# Altium schematic sheet Sensors.SchDoc
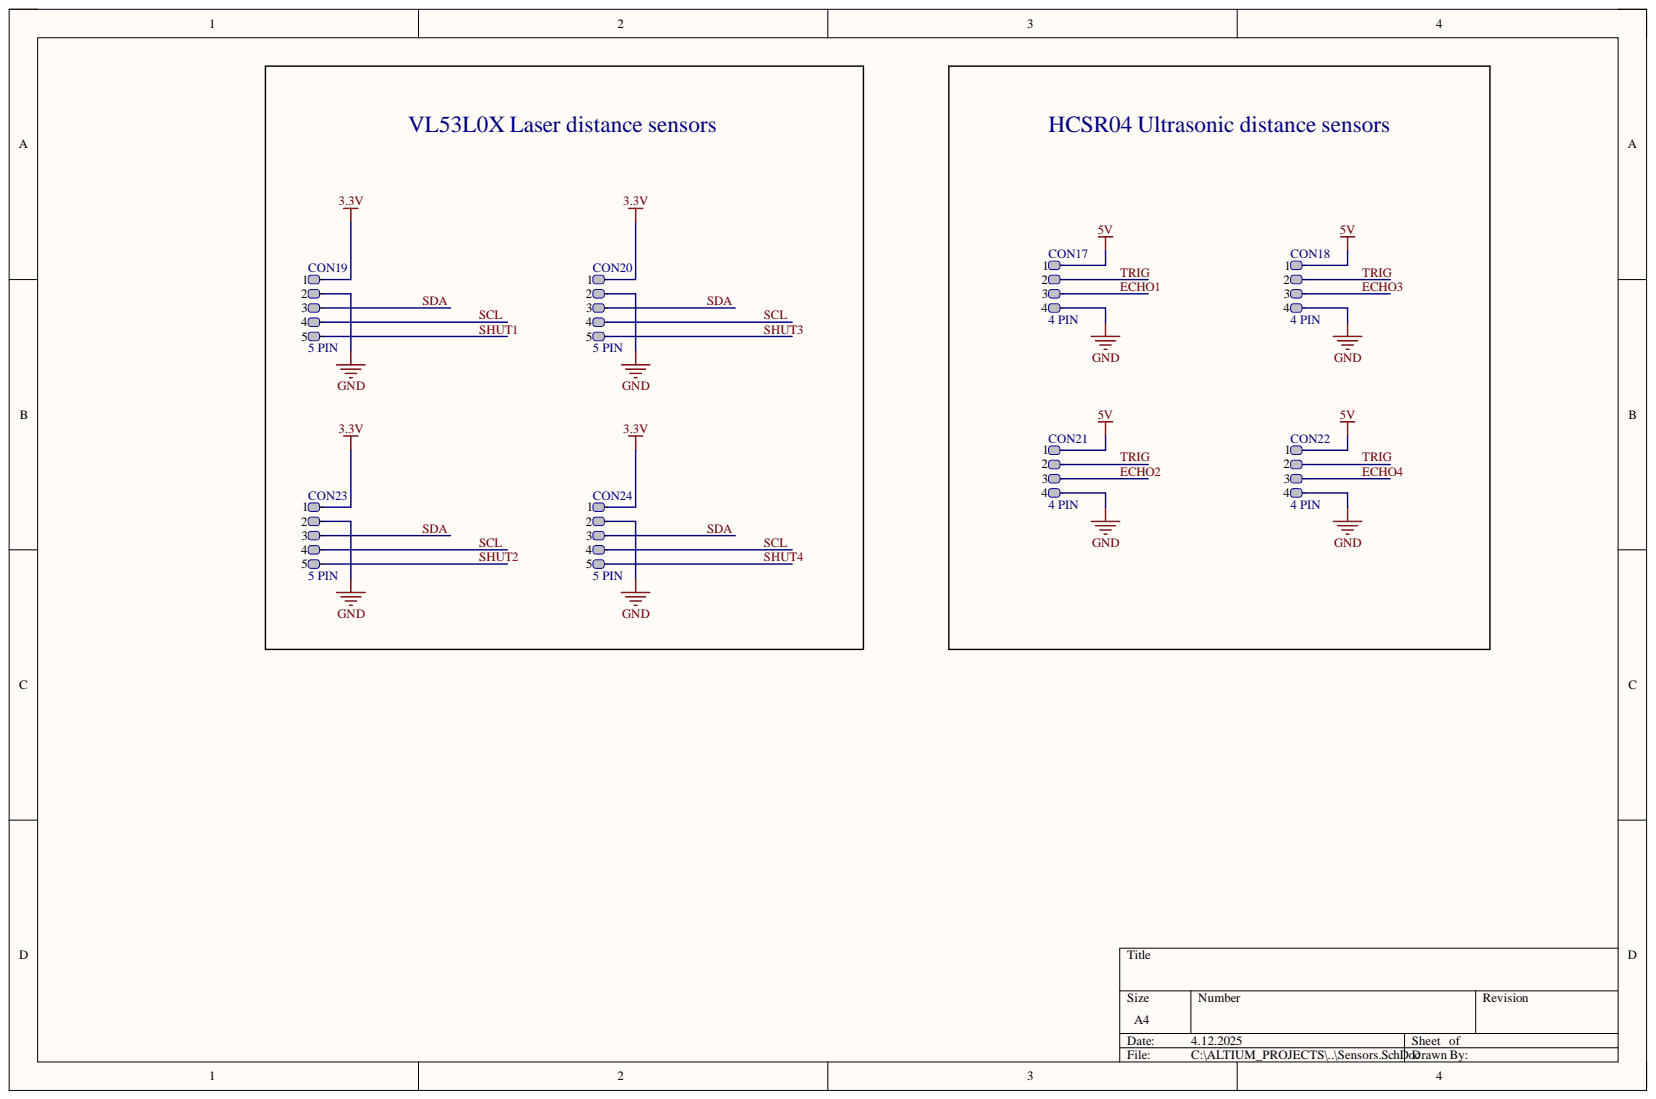
[diagram p0: Sensors.SchDoc]

## Parts
CON17  4 PIN  [Goldpin 2.54 4P]
CON18  4 PIN  [Goldpin 2.54 4P]
CON19  5 PIN  [Goldpin 2.54mm  5Pin]
CON20  5 PIN  [Goldpin 2.54mm  5Pin]
CON21  4 PIN  [Goldpin 2.54 4P]
CON22  4 PIN  [Goldpin 2.54 4P]
CON23  5 PIN  [Goldpin 2.54mm  5Pin]
CON24  5 PIN  [Goldpin 2.54mm  5Pin]

## Nets
3.3V: CON19.1 CON20.1 CON23.1 CON24.1
5V: CON17.1 CON18.1 CON21.1 CON22.1
GND: CON17.4 CON18.4 CON19.2 CON20.2 CON21.4 CON22.4 CON23.2 CON24.2
ECHO1: CON17.3
ECHO2: CON21.3
ECHO3: CON18.3
ECHO4: CON22.3
SCL: CON19.4 CON20.4 CON23.4 CON24.4
SDA: CON19.3 CON20.3 CON23.3 CON24.3
SHUT1: CON19.5
SHUT2: CON23.5
SHUT3: CON20.5
SHUT4: CON24.5
TRIG: CON17.2 CON18.2 CON21.2 CON22.2

## Library data of the parts
CON17 CON18 CON21 CON22 = 4 PIN: Component Kind: Standard; Library Name: Connectors.IntLib
CON19 CON20 CON23 CON24 = 5 PIN: Component Kind: Standard; Library Name: Connectors.IntLib
